# Altium schematic sheet 010_SoCUSB.SchDoc(010_SoCUSB)
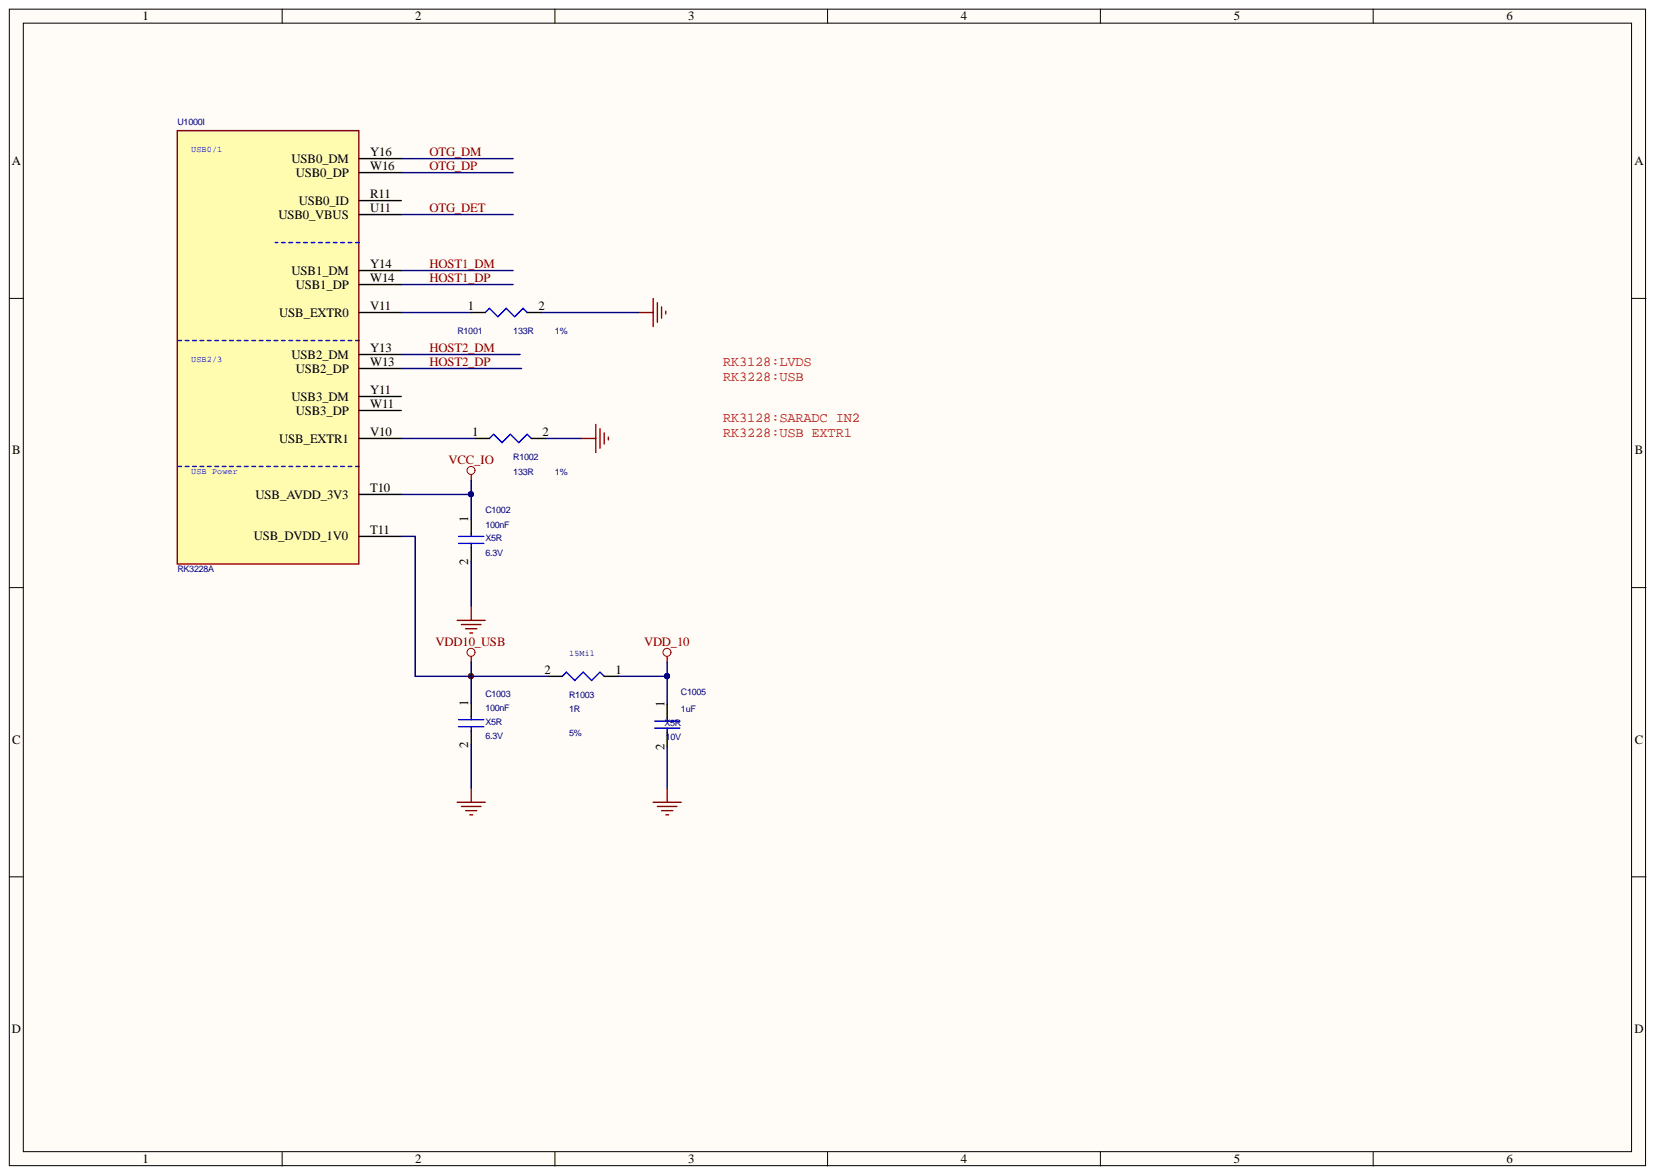
[diagram p0: 010_SoCUSB.SchDoc]

## Parts
C1002  100nF  Voltage: 6.3V  Dielectric: X5R  [C0402]
C1003  100nF  Voltage: 6.3V  Dielectric: X5R  [C0402]
C1005  1uF  Voltage: 10V  Dielectric: X5R  [C0402]
R1001  133R  Tolerance: 1%  [R0402]
R1002  133R  Tolerance: 1%  [R0402]
R1003  1R  [R0402]
U1000  RK3228A  [BGA316-14X14_0.65]  (part I)

## Nets
GND: C1002.2 C1003.2 C1005.2 R1001.2 R1002.2
VCC_IO: C1002.1 U1000.T10(USB_AVDD_3V3)
VDD10_USB: C1003.1 R1003.2 U1000.T11(USB_DVDD_1V0)
VDD_10: C1005.1 R1003.1
HOST1_DM: U1000.Y14(USB1_DM)
HOST1_DP: U1000.W14(USB1_DP)
HOST2_DM: U1000.Y13(USB2_DM)
HOST2_DP: U1000.W13(USB2_DP)
OTG_DET: U1000.U11(USB0_VBUS)
OTG_DM: U1000.Y16(USB0_DM)
OTG_DP: U1000.W16(USB0_DP)
NetR1001_1: R1001.1 U1000.V11(USB_EXTR0)
NetR1002_1: R1002.1 U1000.V10(USB_EXTR1)

Not connected: U1000 R11(USB0_ID) W11(USB3_DP) Y11(USB3_DM)

## Library data of the parts
C1002 C1003 = CAP NP_Dup5: cap,100.00nF,+/-10%,6.3V,X5R,C0402; Manufacturer: TDK; Tolerance: 10%; RK PN: C1005X5R0J104K050BA; Priority: A; Number: 37; Part Type: C0402; Manufacturer PN: C1005X5R0J104K050BA; Component Kind: Standard; C_Voltage: 6.3V; Created by: JJJ
C1005 = CAP NP_Dup5: cap,1.00uF,+/-10%,10V,X5R,C0402; Manufacturer: TDK; Tolerance: 10%; RK PN: C1005X5R1A105K050BB; Priority: A; Number: 37; C_Voltage: 6.3V; Part Type: C0402; Manufacturer PN: C1005X5R1A105K050BB; Component Kind: Standard; Created by: JJJ
R1001 R1002 = RESISTOR_Dup1: 哥棨슌훡롯量,133R,+/-1%,R0402,1/16W.; Manufacturer: YAGEO; Wattage: 1/16W; RK PN: RC0402FR-07133RL; Priority: A; Part Type: 哥棨슌훡롯量; Manufacturer PN: RC0402FR-07133RL; Component Kind: Standard; Created by: YTL
R1003 = RESISTOR_Dup1: 哥棨슌훡롯量,0R,+/-5%,R0402,1/16W.; Manufacturer: YAGEO; Wattage: 1/16W; Tolerance: 5%; RK PN: RC0402JR-070RL; Priority: A; Part Type: 哥棨슌훡롯量; Manufacturer PN: RC0402JR-070RL; Component Kind: Standard; Created by: YTL
U1000 = DSP_RK3228: ROCKCHIP CPU; Manufacturer: Rockchip (쐉拱匣); RK PN: RK3228; Priority: A; Part Type: DSP; Manufacturer PN: RK3228; Component Kind: Standard; Created by: JJJ
